# Altium schematic sheet IO.SchDoc
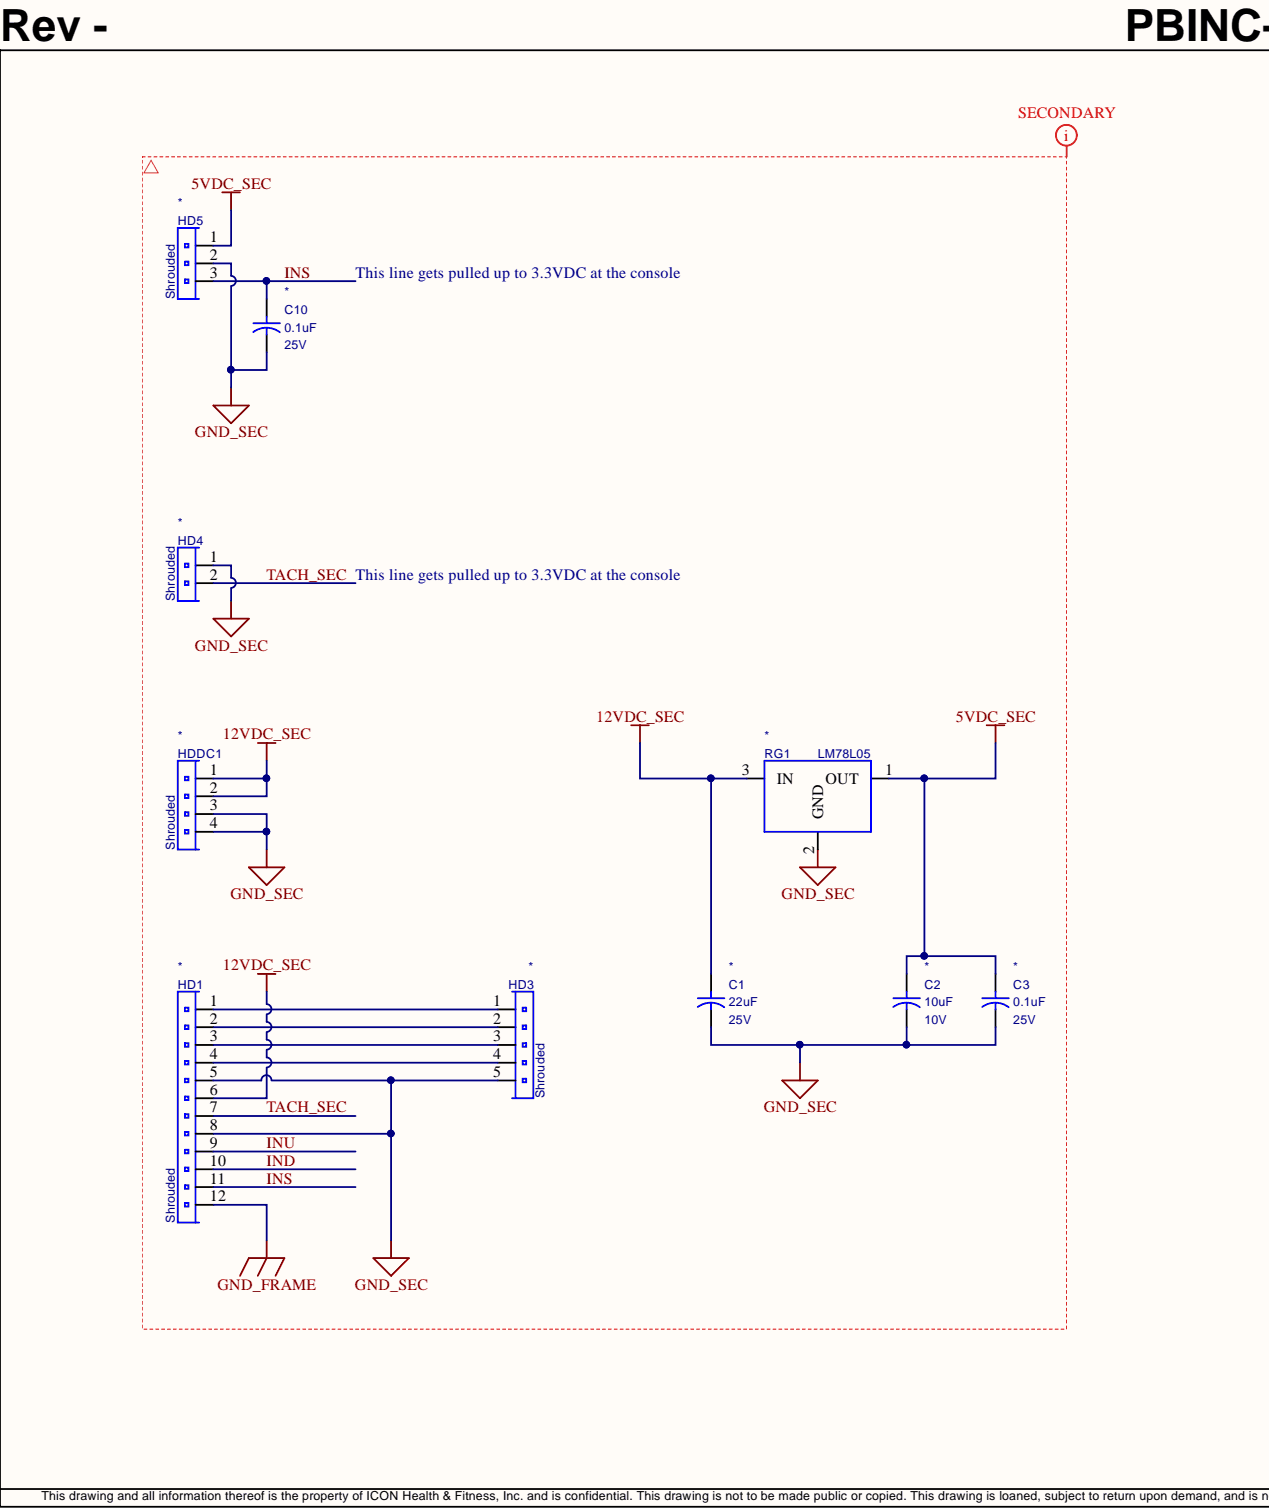
[diagram p0: IO.SchDoc, left]
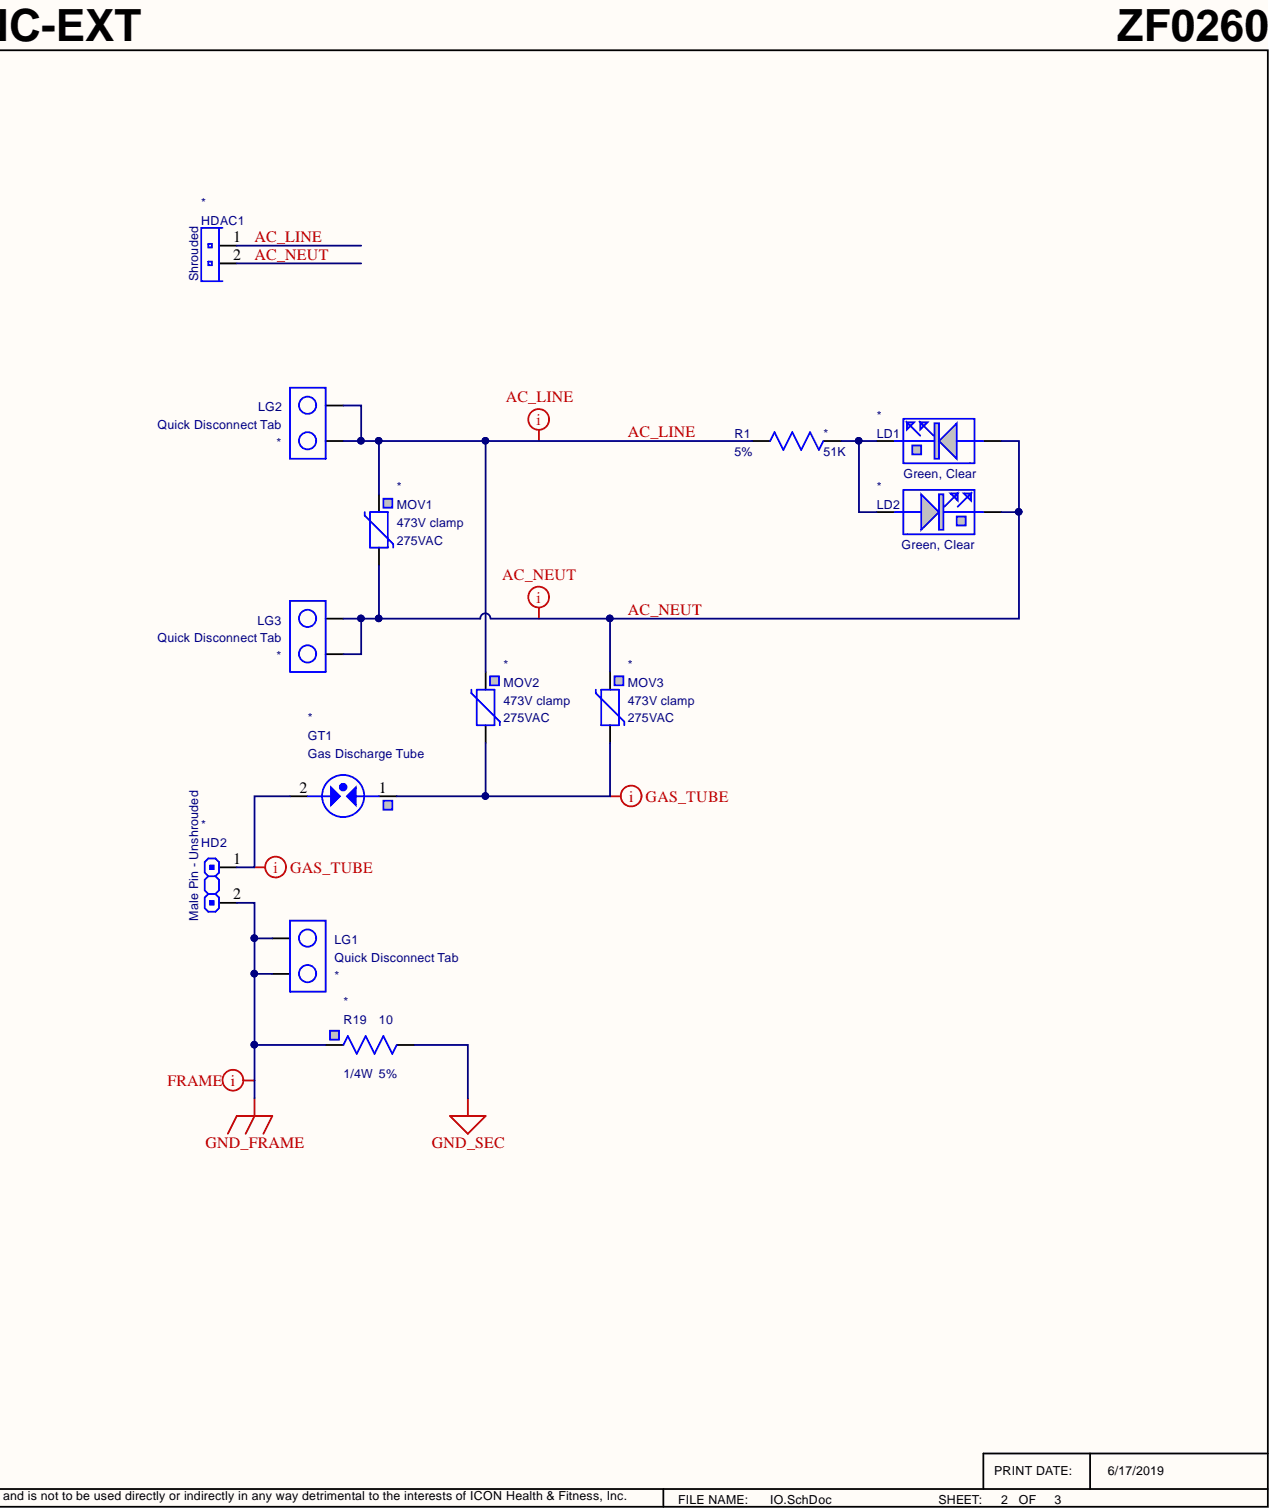
[diagram p1: IO.SchDoc, right]

## Parts
C1  value 22uF  Voltage: 25V  [1210]  (hidden comment: 22uF_Ceramic_25V)
C2  value 10uF  Voltage: 10V  [0603]  (hidden comment: CAP_NON_POLAR_SMT)
C3  value 0.1uF  Voltage: 25V  [0603]  (hidden comment: CAP_NON_POLAR_SMT)
C10  value 0.1uF  Voltage: 25V  [0603]  (hidden comment: CAP_NON_POLAR_SMT)
GT1  value Gas Discharge Tube  [1812]  (hidden comment: GAS_DISCHARGE_TUBE)
HD1  HeaderType: Shrouded  [HDR_2.54MM_12PIN_SHRD_TZ]  (hidden comment: HDR_12PIN)
HD2  HeaderType: Male Pin - Unshrouded  [HDR_5.08MM_2PIN_UNSHRD]  (hidden comment: HDR_3PIN_UNSHRD_NOPIN_2)
HD3  HeaderType: Shrouded  [HDR_2.54MM_5PIN_SHRD_TZ]  (hidden comment: HDR_5PIN)
HD4  HeaderType: Shrouded  [HDR_2.54MM_2PIN_SHRD_TZ]  (hidden comment: HDR_2PIN)
HD5  HeaderType: Shrouded  [HDR_2.54MM_3PIN_SHRD_TZ]  (hidden comment: HDR_3PIN)
HDAC1  HeaderType: Shrouded  [HDR_3.96MM_3PIN_JST_VH_NOPIN2]  (hidden comment: HDR_2PIN)
HDDC1  HeaderType: Shrouded  [HDR_3.96MM_4PIN_JST_VH]  (hidden comment: HDR_4PIN)
LD1  Color: Green, Clear  [0603_LED]  (hidden comment: 0603_GREEN)
LD2  Color: Green, Clear  [0603_LED]  (hidden comment: 0603_GREEN)
LG1  value Quick Disconnect Tab  [SPADE_0.25]  (hidden comment: SPADE_LUG)
LG2  value Quick Disconnect Tab  [SPADE_0.25]  (hidden comment: SPADE_LUG)
LG3  value Quick Disconnect Tab  [SPADE_0.25]  (hidden comment: SPADE_LUG)
MOV1  value 473V clamp  Voltage: 275VAC  [MOV_DISC_7.5mm_5.6mm_14mm]  (hidden comment: MOV_THROUGH_HOLE)
MOV2  value 473V clamp  Voltage: 275VAC  [MOV_DISC_7.5mm_5.6mm_14mm]  (hidden comment: MOV_THROUGH_HOLE)
MOV3  value 473V clamp  Voltage: 275VAC  [MOV_DISC_7.5mm_5.6mm_14mm]  (hidden comment: MOV_THROUGH_HOLE)
R1  value 51K  Tolerance: 5%  [2010]  (hidden comment: RESISTOR_SMT_5%)
R19  value 10  Tolerance: 5%  Wattage: 1/4W  [RES_AXIAL_10mm_6.3mm_2.4mm]  (hidden comment: RESISTOR_THROUGH_HOLE_5%)
RG1  value LM78L05  [TO92_100mil]  (hidden comment: LM78L05_TO92_42)

## Nets
5VDC_SEC: C2.1 C3.1 HD5.1 RG1.1
12VDC_SEC: C1.1 HD1.6 HDDC1.1 HDDC1.2 RG1.3
GND_FRAME: HD1.12 HD2.2 LG1.1 LG1.2 R19.1
GND_SEC: C1.2 C2.2 C3.2 C10.2 HD1.5 HD1.8 HD3.5 HD4.1 HD5.2 HDDC1.3 HDDC1.4 R19.2 RG1.2
AC_LINE: HDAC1.1 LG2.1 LG2.2 MOV1.1 MOV2.1 R1.2
AC_NEUT: HDAC1.2 LD1.2 LD2.1 LG3.1 LG3.2 MOV1.2 MOV3.1
IND: HD1.10
INS: C10.1 HD1.11 HD5.3
INU: HD1.9
TACH_SEC: HD1.7 HD4.2
NetGT1_1: GT1.1 MOV2.2 MOV3.2
NetGT1_2: GT1.2 HD2.1
NetHD1_1: HD1.1 HD3.1
NetHD1_2: HD1.2 HD3.2
NetHD1_3: HD1.3 HD3.3
NetHD1_4: HD1.4 HD3.4
NetLD1_1: LD1.1 LD2.2 R1.1
N00031:

## Library data of the parts
C1 = 22662: Capacitor, 22uF, 25V, Y5V, Ceramic, +80% -20%, Non-Polar, 1210, TDK: C3225Y5V1E226Z or equivalent; Database Table Name: AltiumComponents; CapType: Y5V; Component Kind: Standard; ComponentLink1Description: Datasheet; ComponentLink1URL: http://media.digikey.com/pdf/Data%20Sheets/TDK%20PDFs/C_Series_GeneralAppl_B11.pdf; ComponentLink4Description: PDM Parts Maintenance; ComponentLink4URL: https://pdm.iconfitness.com/PDM/EBOM/PartsMaintenance/PartsMaintenance.aspx?Editplidx=22662; ComponentType: Capacitor; Composition: Ceramic; Cost: 0.03; Package: 1210; plIDX: 22662; Polarity: Non-Polar; Tolerance: +80% -20%; Vendor: TDK: C3225Y5V1E226Z or equivalent; CheckedBy: gdeguzman; LastUpdatedBy: Mike Tyger; Library Name: bome.DbLib
C2 = 304064: Capacitor, 10uF, 10V, Ceramic, X5R, ±10%, Non-Polar, 0603, Fenghua: 0603X106K100N or equivalent; Database Table Name: AltiumComponents; CapType: Ceramic; Component Kind: Standard; ComponentLink1Description: Datasheet; ComponentLink1URL: \\iconfitness.com\loganfiles\RND Departments\electronics\accel_lib\Datasheets\c02e.pdf; ComponentLink2Description: Datsheet2; ComponentLink2URL: http://www.passivecomponent.com/indexsea/ASC_General_Purpose.pdf; ComponentLink4Description: PDM Parts Maintenance; ComponentLink4URL: https://pdm.iconfitness.com/PDM/EBOM/PartsMaintenance/PartsMaintenance.aspx?Editplidx=304064; ComponentType: Capacitor; Composition: X5R; Cost: 0.0085; Package: 0603; plIDX: 304064; Polarity: Non-Polar; Tolerance: ±10%; Vendor: Fenghua: 0603X106K100N or equivalent; CheckedBy: Sam Rhoufiry; CreatedBy: Sara Lin; LastUpdatedBy: Mike Tyger; Library Name: bome.DbLib
C3 C10 = 18829: Capacitor, 0.1uF, 25V, Ceramic, Y5V, ±20%, Non-Polar, 0603, Kemet: C0603C104M3VACTU or equivalent; Database Table Name: AltiumComponents; CapType: Ceramic; Component Kind: Standard; ComponentLink4Description: PDM Parts Maintenance; ComponentLink4URL: https://pdm.iconfitness.com/PDM/EBOM/PartsMaintenance/PartsMaintenance.aspx?Editplidx=18829; ComponentType: Capacitor; Composition: Y5V; Cost: 0.0035; Package: 0603; plIDX: 18829; Polarity: Non-Polar; Tolerance: ±20%; Vendor: Kemet: C0603C104M3VACTU or equivalent; CheckedBy: Sam Rhoufiry; LastUpdatedBy: Mike Tyger; Library Name: bome.DbLib
GT1 = 311593: MOV, 300VDC Breakdown, Gas Discharge Tube, 1812, Bourns: 2051-30-SM-RPLF; Database Table Name: AltiumComponents; Vendor: Bourns: 2051-30-SM-RPLF, Okaya: RHCA-301P43U, Thinking: GF32R300MRC; Component Kind: Standard; ComponentLink4Description: PDM Parts Maintenance; ComponentLink4URL: https://pdm.iconfitness.com/PDM/EBOM/PartsMaintenance/PartsMaintenance.aspx?Editplidx=311593; ComponentType: MOV; Cost: 0.1117; Package: 1812; plIDX: 311593; Voltage: 300VDC Breakdown; CreatedBy: Mike Tyger; LastUpdatedBy: Mike Tyger; Library Name: bome.DbLib
HD1 = 334648: Header, 12 Pins, 2.54mm, Shrouded, Ting Zi:018-W012IP no alternates; Database Table Name: AltiumComponents; Component Kind: Standard; ComponentLink1Description: Datasheet; ComponentLink1URL: \\iconfitness.com\loganfiles\RND Departments\electronics\accel_lib\Datasheets\TZ-018-W0XXIP.pdf; ComponentLink4Description: PDM Parts Maintenance; ComponentLink4URL: https://pdm.iconfitness.com/PDM/EBOM/PartsMaintenance/PartsMaintenance.aspx?Editplidx=334648; ComponentType: Header; Cost: 0.16; LeadSpacing: 2.54mm; Pins: 12; plIDX: 334648; Vendor: Ting Zi:018-W012IP no alternates; CreatedBy: Mike Tyger; LastUpdatedBy: Mike Tyger; Library Name: bome.DbLib
HD2 = 334748: Header, 2 Pins, 0.100", 3 position, Male Pin - Unshrouded, TE: 3-644748-1 or equivalent; Database Table Name: AltiumComponents; Component Kind: Standard; ComponentLink4Description: PDM Parts Maintenance; ComponentLink4URL: https://pdm.iconfitness.com/PDM/EBOM/PartsMaintenance/PartsMaintenance.aspx?Editplidx=334748; ComponentType: Header; Cost: 0; LeadSpacing: 0.100"; Package: 3 position; Pins: 2; plIDX: 334748; Vendor: TE: 3-644748-1 or equivalent; CreatedBy: Mike Tyger; LastUpdatedBy: Mike Tyger; Library Name: bome.DbLib
HD3 = 334643: Header, 5 Pins, 2.54mm, Shrouded, Ting Zi:018-W005IP no alternates; Database Table Name: AltiumComponents; Component Kind: Standard; ComponentLink1Description: Datasheet; ComponentLink1URL: \\iconfitness.com\loganfiles\RND Departments\electronics\accel_lib\Datasheets\TZ-018-W0XXIP.pdf; ComponentLink4Description: PDM Parts Maintenance; ComponentLink4URL: https://pdm.iconfitness.com/PDM/EBOM/PartsMaintenance/PartsMaintenance.aspx?Editplidx=334643; ComponentType: Header; Cost: 0.074; LeadSpacing: 2.54mm; Pins: 5; plIDX: 334643; Vendor: Ting Zi:018-W005IP no alternates; CreatedBy: Mike Tyger; LastUpdatedBy: Mike Tyger; Library Name: bome.DbLib
HD4 = 334640: Header, 2 Pins, 2.54mm, Shrouded, Ting Zi:018-W002IP no alternates; Database Table Name: AltiumComponents; Component Kind: Standard; ComponentLink1Description: Datasheet; ComponentLink1URL: \\iconfitness.com\loganfiles\RND Departments\electronics\accel_lib\Datasheets\TZ-018-W0XXIP.pdf; ComponentLink4Description: PDM Parts Maintenance; ComponentLink4URL: https://pdm.iconfitness.com/PDM/EBOM/PartsMaintenance/PartsMaintenance.aspx?Editplidx=334640; ComponentType: Header; Cost: 0; LeadSpacing: 2.54mm; Pins: 2; plIDX: 334640; Vendor: Ting Zi:018-W002IP no alternates; CreatedBy: Mike Tyger; LastUpdatedBy: Mike Tyger; Library Name: bome.DbLib
HD5 = 334641: Header, 3 Pins, 2.54mm, Shrouded, Ting Zi:018-W003IP no alternates; Database Table Name: AltiumComponents; Component Kind: Standard; ComponentLink1Description: Datasheet; ComponentLink1URL: \\iconfitness.com\loganfiles\RND Departments\electronics\accel_lib\Datasheets\TZ-018-W0XXIP.pdf; ComponentLink4Description: PDM Parts Maintenance; ComponentLink4URL: https://pdm.iconfitness.com/PDM/EBOM/PartsMaintenance/PartsMaintenance.aspx?Editplidx=334641; ComponentType: Header; Cost: 0.051; LeadSpacing: 2.54mm; Pins: 3; plIDX: 334641; Vendor: Ting Zi:018-W003IP no alternates; CreatedBy: Mike Tyger; LastUpdatedBy: Mike Tyger; Library Name: bome.DbLib
HDAC1 = 349940: Header, 2 Pins, 3.96mm Pitch, Vertical, Through hole, single row (Pin 2 not loaded), Shrouded, JST: B3P-VH (Pin 2 not loaded), CJT: A3963WV-3P (Pin 2 not loaded); Database Table Name: AltiumComponents; Component Kind: Standard; ComponentLink1Description: Datasheet; ComponentLink4Description: PDM Parts Maintenance; ComponentLink4URL: https://pdm.iconfitness.com/PDM/EBOM/PartsMaintenance/PartsMaintenance.aspx?Editplidx=349940; ComponentType: Header; Cost: 0; LeadSpacing: 3.96mm Pitch; Package: Vertical, Through hole, single row (Pin 2 not loaded); Pins: 2; plIDX: 349940; Vendor: JST: B3P-VH (Pin 2 not loaded), CJT: A3963WV-3P (Pin 2 not loaded); CreatedBy: Mike Tyger; LastUpdatedBy: Mike Tyger; Library Name: bome.DbLib
HDDC1 = 349921: Header, 4 Pins, 3.96mm Pitch, Vertical, Through hole, single row, Shrouded, JST: B4P-VH, CJT: A3963WV-4P; Database Table Name: AltiumComponents; Component Kind: Standard; ComponentLink1Description: Datasheet; ComponentLink4Description: PDM Parts Maintenance; ComponentLink4URL: https://pdm.iconfitness.com/PDM/EBOM/PartsMaintenance/PartsMaintenance.aspx?Editplidx=349921; ComponentType: Header; Cost: 0; LeadSpacing: 3.96mm Pitch; Package: Vertical, Through hole, single row; Pins: 4; plIDX: 349921; Vendor: JST: B4P-VH, CJT: A3963WV-4P; CreatedBy: Mike Tyger; LastUpdatedBy: Mike Tyger; Library Name: bome.DbLib
LD1 LD2 = 9505: LED, Green, Clear, Rectangle, Diffused, 0603, Vendor: Lite-On, LTST-C190KGKT or Equivalent; Database Table Name: AltiumComponents; Component Kind: Standard; ComponentLink4Description: PDM Parts Maintenance; ComponentLink4URL: https://pdm.iconfitness.com/PDM/EBOM/PartsMaintenance/PartsMaintenance.aspx?Editplidx=9505; ComponentType: LED; Cost: 0.022; LEDType: Diffused; Package: 0603; plIDX: 9505; Shape: Rectangle; Vendor: Lite-On; VendorNumber: LTST-C190KGKT or Equivalent; CheckedBy: Richard Simmons; LastUpdatedBy: Mike Tyger; Library Name: bome.DbLib
LG1-LG3 = 265185: Header, 0.25", Ting Zi: 025-3489-01 or equivalent; Database Table Name: AltiumComponents; Component Kind: Standard; ComponentLink1Description: Datasheet; ComponentLink1URL: \\iconfitness.com\loganfiles\RND Departments\Electronics\accel_lib\025-3489-01.pdf; ComponentLink4Description: PDM Parts Maintenance; ComponentLink4URL: https://pdm.iconfitness.com/PDM/EBOM/PartsMaintenance/PartsMaintenance.aspx?Editplidx=265185; ComponentType: Header; Cost: 0.0125; HeaderType: 0.25"; plIDX: 265185; Vendor: Ting Zi: 025-3489-01 or equivalent; CheckedBy: gdeguzman; CreatedBy: Mike Tyger; LastUpdatedBy: Mike Tyger; Library Name: bome.DbLib
MOV1-MOV3 = 265263: MOV, 275VAC, 473V clamp, 7.5mm, Radial Disc 14mm, Panasonic: ERZV14D431, Littelfuse: V275LA20AP, CNR: CNR14D431K, Joyin: JVR14N431K87; Database Table Name: AltiumComponents; Component Kind: Standard; ComponentLink4Description: PDM Parts Maintenance; ComponentLink4URL: https://pdm.iconfitness.com/PDM/EBOM/PartsMaintenance/PartsMaintenance.aspx?Editplidx=265263; ComponentType: MOV; Cost: 0.07; LeadSpacing: 7.5mm; Package: Radial Disc 14mm; plIDX: 265263; Vendor: Panasonic: ERZV14D431, Littelfuse: V275LA20AP, CNR: CNR14D431K, Joyin: JVR14N431K87; CreatedBy: Mike Tyger; LastUpdatedBy: Mike Tyger; Library Name: bome.DbLib
R1 = 19368: Resistor, 51K, ThickFilm, 5%, 2010, 1/2W, Any; Database Table Name: AltiumComponents; Component Kind: Standard; ComponentLink4Description: PDM Parts Maintenance; ComponentLink4URL: https://pdm.iconfitness.com/PDM/EBOM/PartsMaintenance/PartsMaintenance.aspx?Editplidx=19368; ComponentType: Resistor; Composition: ThickFilm; Cost: 0.001; Package: 2010; plIDX: 19368; Vendor: Any; Wattage: 1/2W; CheckedBy: gdeguzman; LastUpdatedBy: Mike Tyger; Library Name: bome.DbLib
R19 = 264971: Resistor, 10, Carbon Film, 5%, 10mm, Axial, 1/4W, Any; Database Table Name: AltiumComponents; Component Kind: Standard; ComponentLink4Description: PDM Parts Maintenance; ComponentLink4URL: https://pdm.iconfitness.com/PDM/EBOM/PartsMaintenance/PartsMaintenance.aspx?Editplidx=264971; ComponentType: Resistor; Composition: Carbon Film; Cost: 0.0034; LeadSpacing: 10mm; Package: Axial; plIDX: 264971; Vendor: Any; CheckedBy: gdeguzman; CreatedBy: Mike Tyger; LastUpdatedBy: Mike Tyger; Library Name: bome.DbLib
RG1 = 1803: Regulator, LM78L05, 5V, 0.1 in., TO-92, 100mA, 500mW, Positive; Database Table Name: AltiumComponents; LeadSpacing: 0.1 in.; Amperage: 100mA; Component Kind: Standard; ComponentLink4Description: PDM Parts Maintenance; ComponentLink4URL: https://pdm.iconfitness.com/PDM/EBOM/PartsMaintenance/PartsMaintenance.aspx?Editplidx=1803; ComponentType: Regulator; Cost: 0.03; ModifyDate: 07/10/2007 16:11:28; Package: TO-92; plIDX: 1803; RegulatorType: Positive; Voltage: 5V; Wattage: 500mW; LastUpdatedBy: Mike Tyger; Library Name: bome.DbLib
